# Altium schematic sheet Matrix_driver.SchDoc(Matrix_driver)
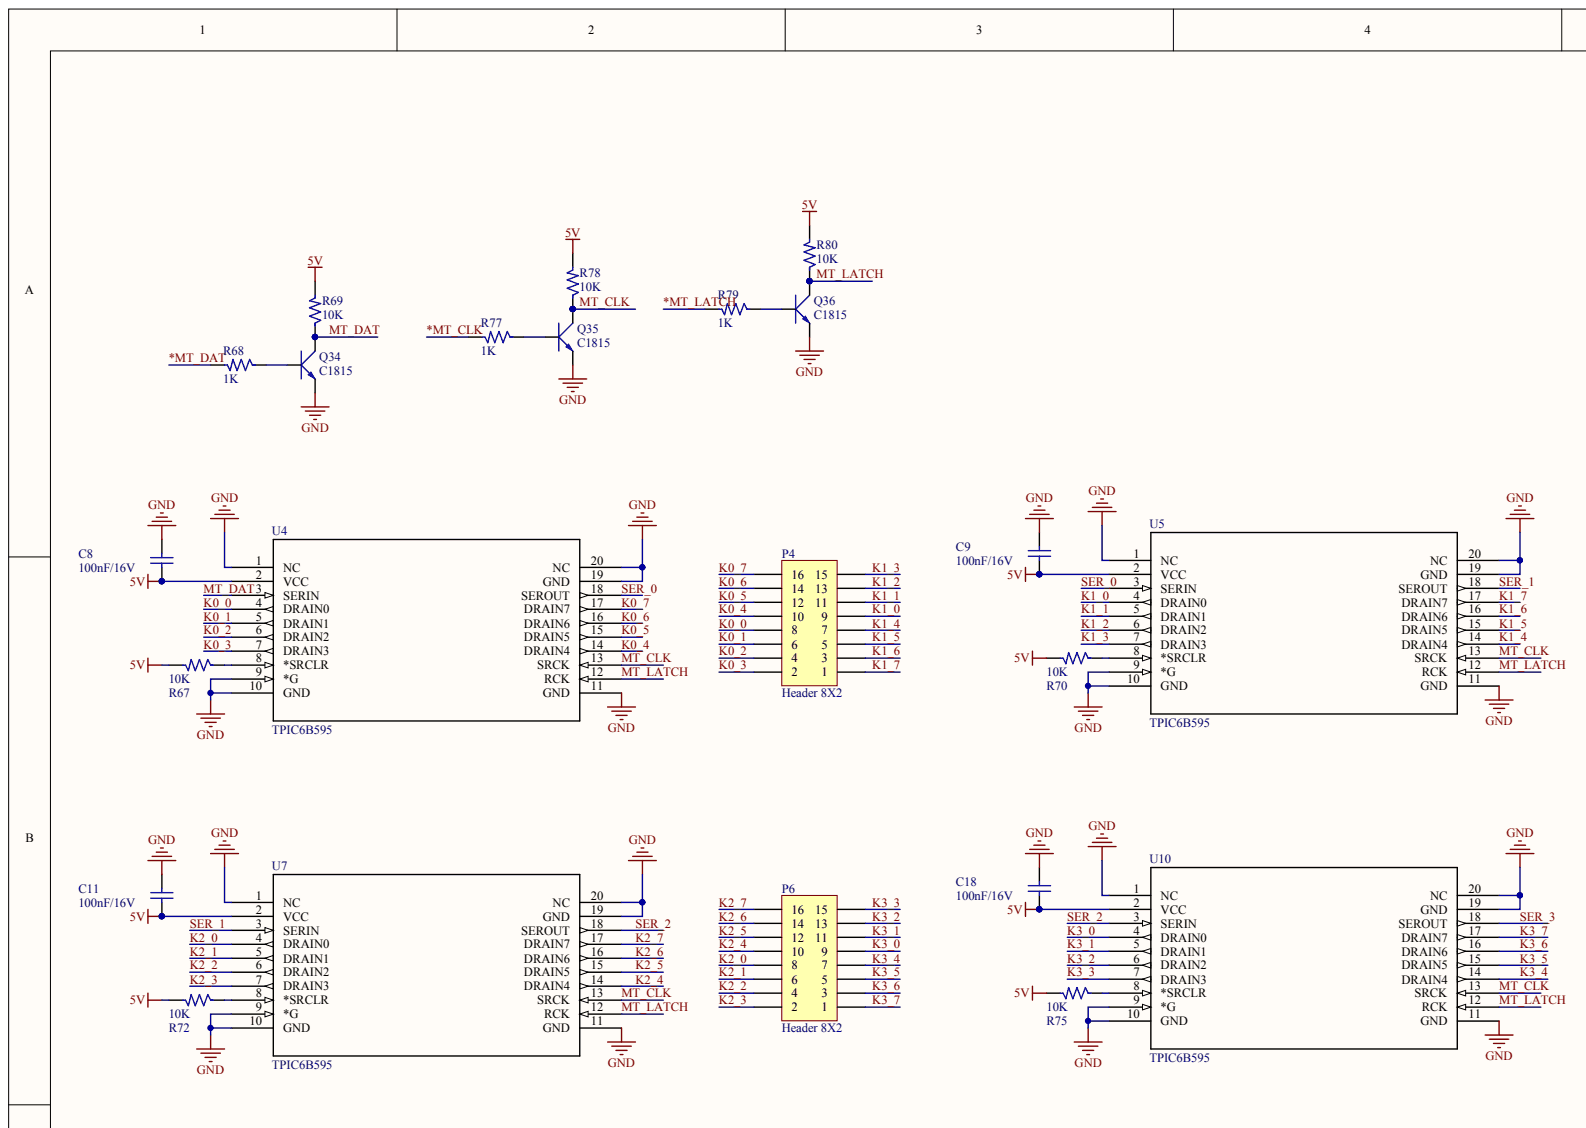
[diagram p0: Matrix_driver.SchDoc, top left]
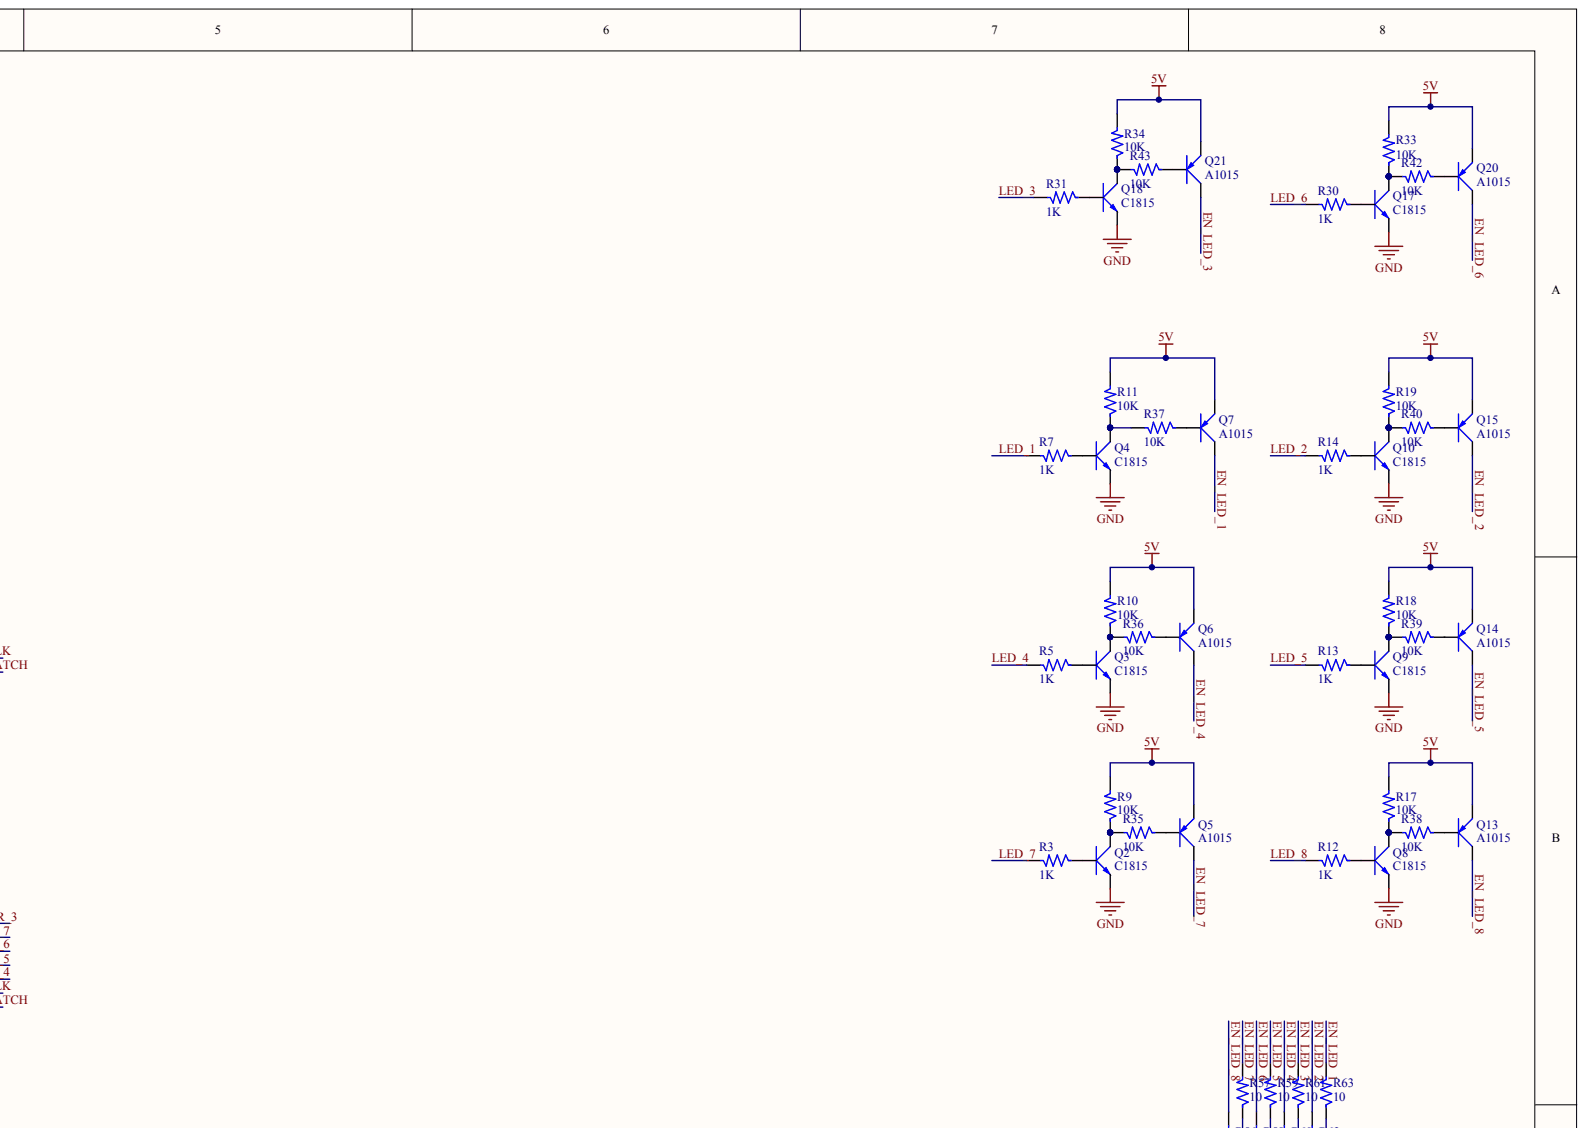
[diagram p1: Matrix_driver.SchDoc, top right]
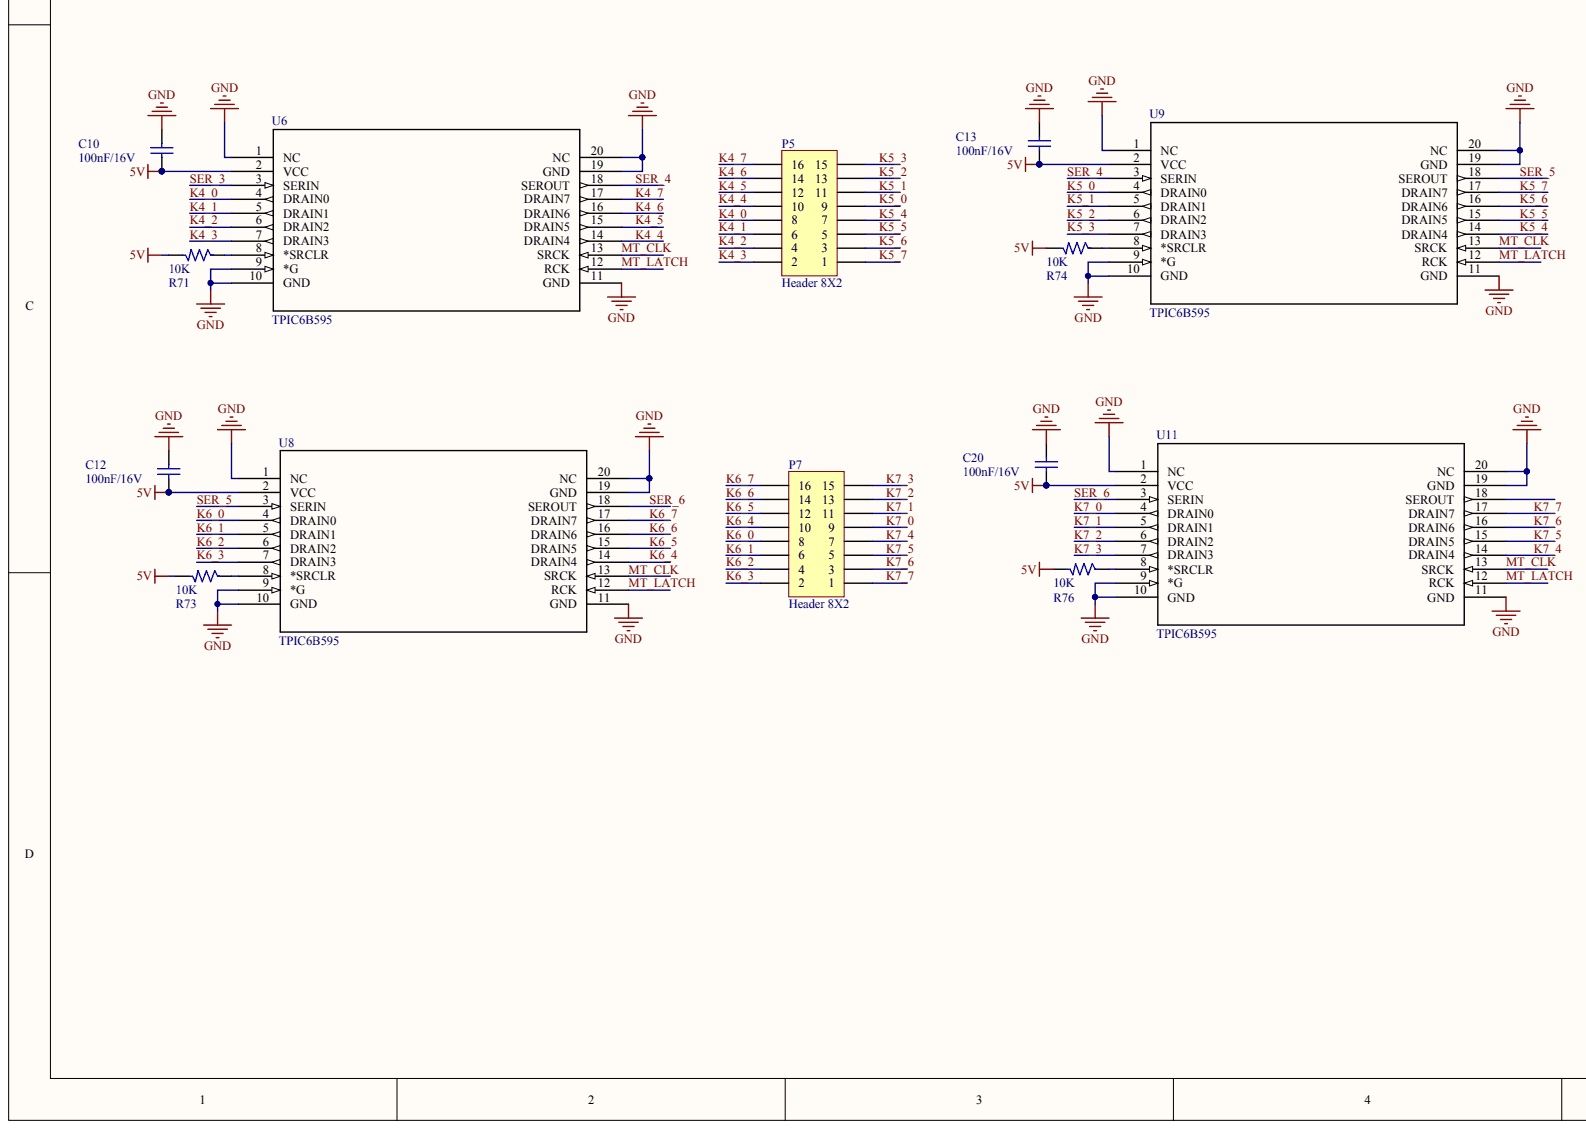
[diagram p2: Matrix_driver.SchDoc, bottom left]
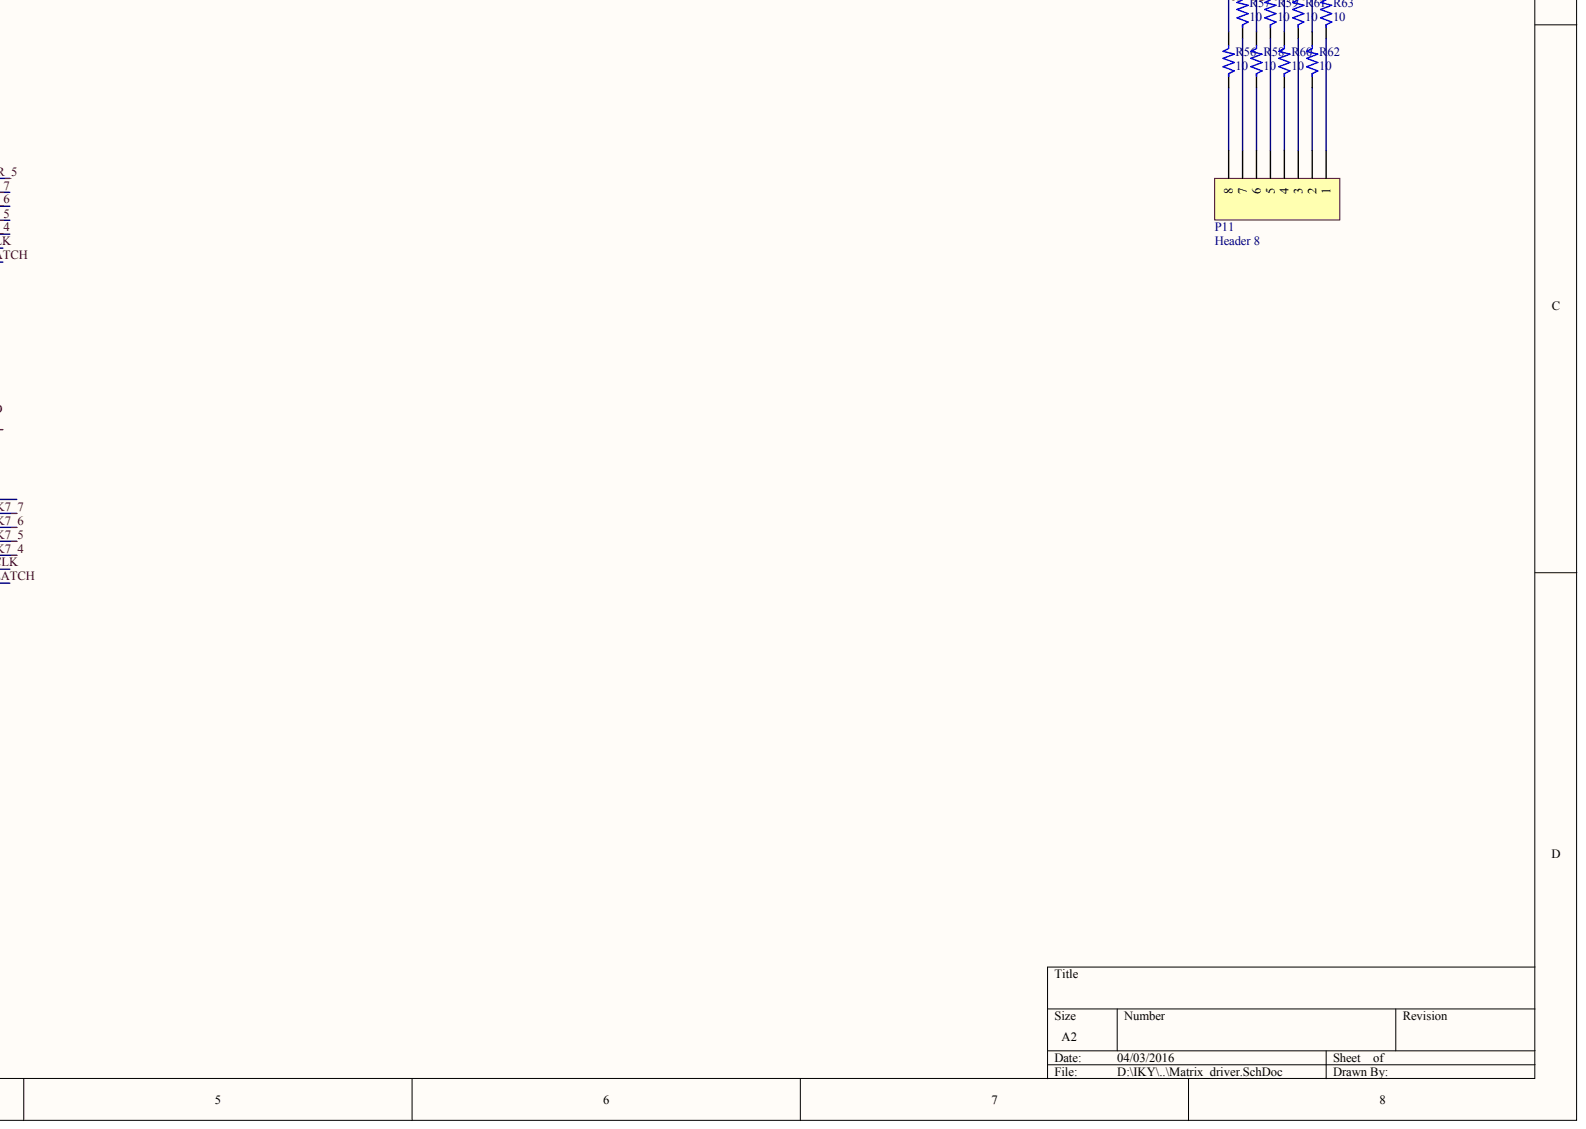
[diagram p3: Matrix_driver.SchDoc, bottom right]

## Parts
C8  100nF/16V
C9  100nF/16V
C10  100nF/16V
C11  100nF/16V
C12  100nF/16V
C13  100nF/16V
C18  100nF/16V
C20  100nF/16V
P4  Header 8X2
P5  Header 8X2
P6  Header 8X2
P7  Header 8X2
P11  Header 8
Q2  C1815
Q3  C1815
Q4  C1815
Q5  A1015
Q6  A1015
Q7  A1015
Q8  C1815
Q9  C1815
Q10  C1815
Q13  A1015
Q14  A1015
Q15  A1015
Q17  C1815
Q18  C1815
Q20  A1015
Q21  A1015
Q34  C1815
Q35  C1815
Q36  C1815
R3  1K
R5  1K
R7  1K
R9  10K
R10  10K
R11  10K
R12  1K
R13  1K
R14  1K
R17  10K
R18  10K
R19  10K
R30  1K
R31  1K
R33  10K
R34  10K
R35  10K
R36  10K
R37  10K
R38  10K
R39  10K
R40  10K
R42  10K
R43  10K
R56  10
R57  10
R58  10
R59  10
R60  10
R61  10
R62  10
R63  10
R67  10K
R68  1K
R69  10K
R70  10K
R71  10K
R72  10K
R73  10K
R74  10K
R75  10K
R76  10K
R77  1K
R78  10K
R79  1K
R80  10K
U4  TPIC6B595
U5  TPIC6B595
U6  TPIC6B595
U7  TPIC6B595
U8  TPIC6B595
U9  TPIC6B595
U10  TPIC6B595
U11  TPIC6B595

## Nets
5V: C8.1 C9.1 C10.1 C11.1 C12.1 C13.1 C18.1 C20.1 Q5.2 Q6.2 Q7.2 Q13.2 Q14.2 Q15.2 Q20.2 Q21.2 R9.2 R10.2 R11.2 R17.2 R18.2 R19.2 R33.2 R34.2 R67.2 R69.2 R70.2 R71.2 R72.2 R73.2 R74.2 R75.2 R76.2 R78.2 R80.2 U4.2(VCC) U5.2(VCC) U6.2(VCC) U7.2(VCC) U8.2(VCC) U9.2(VCC) U10.2(VCC) U11.2(VCC)
GND: C8.2 C9.2 C10.2 C11.2 C12.2 C13.2 C18.2 C20.2 Q2.2 Q3.2 Q4.2 Q8.2 Q9.2 Q10.2 Q17.2 Q18.2 Q34.2 Q35.2 Q36.2 U4.1(NC) U4.9(*G) U4.10(GND) U4.11(GND) U4.19(GND) U4.20(NC) U5.1(NC) U5.9(*G) U5.10(GND) U5.11(GND) U5.19(GND) U5.20(NC) U6.1(NC) U6.9(*G) U6.10(GND) U6.11(GND) U6.19(GND) U6.20(NC) U7.1(NC) U7.9(*G) U7.10(GND) U7.11(GND) U7.19(GND) U7.20(NC) U8.1(NC) U8.9(*G) U8.10(GND) U8.11(GND) U8.19(GND) U8.20(NC) U9.1(NC) U9.9(*G) U9.10(GND) U9.11(GND) U9.19(GND) U9.20(NC) U10.1(NC) U10.9(*G) U10.10(GND) U10.11(GND) U10.19(GND) U10.20(NC) U11.1(NC) U11.9(*G) U11.10(GND) U11.11(GND) U11.19(GND) U11.20(NC)
*MT_CLK: R77.2
*MT_DAT: R68.2
*MT_LATCH: R79.2
EN_LED_1: Q7.3 R63.2
EN_LED_2: Q15.3 R62.2
EN_LED_3: Q21.3 R61.2
EN_LED_4: Q6.3 R60.2
EN_LED_5: Q14.3 R59.2
EN_LED_6: Q20.3 R58.2
EN_LED_7: Q5.3 R57.2
EN_LED_8: Q13.3 R56.2
K0_0: P4.8 U4.4(DRAIN0)
K0_1: P4.6 U4.5(DRAIN1)
K0_2: P4.4 U4.6(DRAIN2)
K0_3: P4.2 U4.7(DRAIN3)
K0_4: P4.10 U4.14(DRAIN4)
K0_5: P4.12 U4.15(DRAIN5)
K0_6: P4.14 U4.16(DRAIN6)
K0_7: P4.16 U4.17(DRAIN7)
K1_0: P4.9 U5.4(DRAIN0)
K1_1: P4.11 U5.5(DRAIN1)
K1_2: P4.13 U5.6(DRAIN2)
K1_3: P4.15 U5.7(DRAIN3)
K1_4: P4.7 U5.14(DRAIN4)
K1_5: P4.5 U5.15(DRAIN5)
K1_6: P4.3 U5.16(DRAIN6)
K1_7: P4.1 U5.17(DRAIN7)
K2_0: P6.8 U7.4(DRAIN0)
K2_1: P6.6 U7.5(DRAIN1)
K2_2: P6.4 U7.6(DRAIN2)
K2_3: P6.2 U7.7(DRAIN3)
K2_4: P6.10 U7.14(DRAIN4)
K2_5: P6.12 U7.15(DRAIN5)
K2_6: P6.14 U7.16(DRAIN6)
K2_7: P6.16 U7.17(DRAIN7)
K3_0: P6.9 U10.4(DRAIN0)
K3_1: P6.11 U10.5(DRAIN1)
K3_2: P6.13 U10.6(DRAIN2)
K3_3: P6.15 U10.7(DRAIN3)
K3_4: P6.7 U10.14(DRAIN4)
K3_5: P6.5 U10.15(DRAIN5)
K3_6: P6.3 U10.16(DRAIN6)
K3_7: P6.1 U10.17(DRAIN7)
K4_0: P5.8 U6.4(DRAIN0)
K4_1: P5.6 U6.5(DRAIN1)
K4_2: P5.4 U6.6(DRAIN2)
K4_3: P5.2 U6.7(DRAIN3)
K4_4: P5.10 U6.14(DRAIN4)
K4_5: P5.12 U6.15(DRAIN5)
K4_6: P5.14 U6.16(DRAIN6)
K4_7: P5.16 U6.17(DRAIN7)
K5_0: P5.9 U9.4(DRAIN0)
K5_1: P5.11 U9.5(DRAIN1)
K5_2: P5.13 U9.6(DRAIN2)
K5_3: P5.15 U9.7(DRAIN3)
K5_4: P5.7 U9.14(DRAIN4)
K5_5: P5.5 U9.15(DRAIN5)
K5_6: P5.3 U9.16(DRAIN6)
K5_7: P5.1 U9.17(DRAIN7)
K6_0: P7.8 U8.4(DRAIN0)
K6_1: P7.6 U8.5(DRAIN1)
K6_2: P7.4 U8.6(DRAIN2)
K6_3: P7.2 U8.7(DRAIN3)
K6_4: P7.10 U8.14(DRAIN4)
K6_5: P7.12 U8.15(DRAIN5)
K6_6: P7.14 U8.16(DRAIN6)
K6_7: P7.16 U8.17(DRAIN7)
K7_0: P7.9 U11.4(DRAIN0)
K7_1: P7.11 U11.5(DRAIN1)
K7_2: P7.13 U11.6(DRAIN2)
K7_3: P7.15 U11.7(DRAIN3)
K7_4: P7.7 U11.14(DRAIN4)
K7_5: P7.5 U11.15(DRAIN5)
K7_6: P7.3 U11.16(DRAIN6)
K7_7: P7.1 U11.17(DRAIN7)
LED_1: R7.2
LED_2: R14.2
LED_3: R31.2
LED_4: R5.2
LED_5: R13.2
LED_6: R30.2
LED_7: R3.2
LED_8: R12.2
MT_CLK: Q35.3 R78.1 U4.13(SRCK) U5.13(SRCK) U6.13(SRCK) U7.13(SRCK) U8.13(SRCK) U9.13(SRCK) U10.13(SRCK) U11.13(SRCK)
MT_DAT: Q34.3 R69.1 U4.3(SERIN)
MT_LATCH: Q36.3 R80.1 U4.12(RCK) U5.12(RCK) U6.12(RCK) U7.12(RCK) U8.12(RCK) U9.12(RCK) U10.12(RCK) U11.12(RCK)
SER_0: U4.18(SEROUT) U5.3(SERIN)
SER_1: U5.18(SEROUT) U7.3(SERIN)
SER_2: U7.18(SEROUT) U10.3(SERIN)
SER_3: U6.3(SERIN) U10.18(SEROUT)
SER_4: U6.18(SEROUT) U9.3(SERIN)
SER_5: U8.3(SERIN) U9.18(SEROUT)
SER_6: U8.18(SEROUT) U11.3(SERIN)
NetP11_1: P11.1 R63.1
NetP11_2: P11.2 R62.1
NetP11_3: P11.3 R61.1
NetP11_4: P11.4 R60.1
NetP11_5: P11.5 R59.1
NetP11_6: P11.6 R58.1
NetP11_7: P11.7 R57.1
NetP11_8: P11.8 R56.1
NetQ2_1: Q2.1 R3.1
NetQ2_3: Q2.3 R9.1 R35.2
NetQ3_1: Q3.1 R5.1
NetQ3_3: Q3.3 R10.1 R36.2
NetQ4_1: Q4.1 R7.1
NetQ4_3: Q4.3 R11.1 R37.2
NetQ5_1: Q5.1 R35.1
NetQ6_1: Q6.1 R36.1
NetQ7_1: Q7.1 R37.1
NetQ8_1: Q8.1 R12.1
NetQ8_3: Q8.3 R17.1 R38.2
NetQ9_1: Q9.1 R13.1
NetQ9_3: Q9.3 R18.1 R39.2
NetQ10_1: Q10.1 R14.1
NetQ10_3: Q10.3 R19.1 R40.2
NetQ13_1: Q13.1 R38.1
NetQ14_1: Q14.1 R39.1
NetQ15_1: Q15.1 R40.1
NetQ17_1: Q17.1 R30.1
NetQ17_3: Q17.3 R33.1 R42.2
NetQ18_1: Q18.1 R31.1
NetQ18_3: Q18.3 R34.1 R43.2
NetQ20_1: Q20.1 R42.1
NetQ21_1: Q21.1 R43.1
NetQ34_1: Q34.1 R68.1
NetQ35_1: Q35.1 R77.1
NetQ36_1: Q36.1 R79.1
NetR67_1: R67.1 U4.8(*SRCLR)
NetR70_1: R70.1 U5.8(*SRCLR)
NetR71_1: R71.1 U6.8(*SRCLR)
NetR72_1: R72.1 U7.8(*SRCLR)
NetR73_1: R73.1 U8.8(*SRCLR)
NetR74_1: R74.1 U9.8(*SRCLR)
NetR75_1: R75.1 U10.8(*SRCLR)
NetR76_1: R76.1 U11.8(*SRCLR)

Not connected: U11 18(SEROUT)

## Library data of the parts
C8-C13 C18 C20 = (no symbol name): Manufacturer: Yageo; Value: 100nF/50V; Unit Price: *; Manufacturer P/N: *; PackageReference: *; Digi-Key P/N: *; Mouser P/N: *; Vender: *; Publisher: Cao Van Huong, vanhuongcao@gmail.com
R3 R5 R7 R12-R14 R30 R31 R56-R63 R68 R77 R79 = (no symbol name): Manufacturer: Yageo; Value: 10K; Manufacturer P/N: *; Unit Price: *; PackageReference: R0805; Digi-Key P/N: *; Mouser P/N: *; Vender: *; Publisher: Cao Van Huong, vanhuongcao@gmail.com
R9-R11 R17-R19 R33-R40 R42 R43 R67 R69-R76 R78 R80 = (no symbol name): Manufacturer: Yageo; Value: 10K; Manufacturer P/N: *; Unit Price: *; PackageReference: R0805; Digi-Key P/N: *; Mouser P/N: *; Vender: *; Publisher: Cao Van Huong, vanhuongcao@gmail.com
U4-U11 = (no symbol name): Manufacturer P/N: 74HC595AN; Value: 75HC595; PackageReference: SOIC 16; Manufacturer: *; Unit Price: *; Digi-Key P/N: *; Mouser P/N: *; Vender: *; Publisher: Cao Van Huong, vanhuongcao@gmail.com
P4-P7 P11 Q2-Q10 Q13-Q15 Q17 Q18 Q20 Q21 Q34-Q36 = (no symbol name)
  P4-P7 P11: LatestRevisionDate: 17-Jul-2002; LatestRevisionNote: Re-released for DXP Platform.; Publisher: Altium Limited
  Q2-Q4 Q8-Q10 Q17 Q18 Q34-Q36: Manufacturer P/N: *; Value: *; Manufacturer: *; Unit Price: *; PackageReference: *; Digi-Key P/N: *; Mouser P/N: *; Vender: *
  Q5-Q7 Q13-Q15 Q20 Q21: Manufacturer: *; Manufacturer P/N: *; Unit Price: *; PackageReference: *; Value: *; Digi-Key P/N: *; Mouser P/N: *; Vender: *
  Q2-Q10 Q13-Q15 Q17 Q18 Q20 Q21 Q34-Q36: Publisher: Cao Van Huong, vanhuongcao@gmail.com
